# Altium schematic sheet lv_com_rs232_rs485.SchDoc
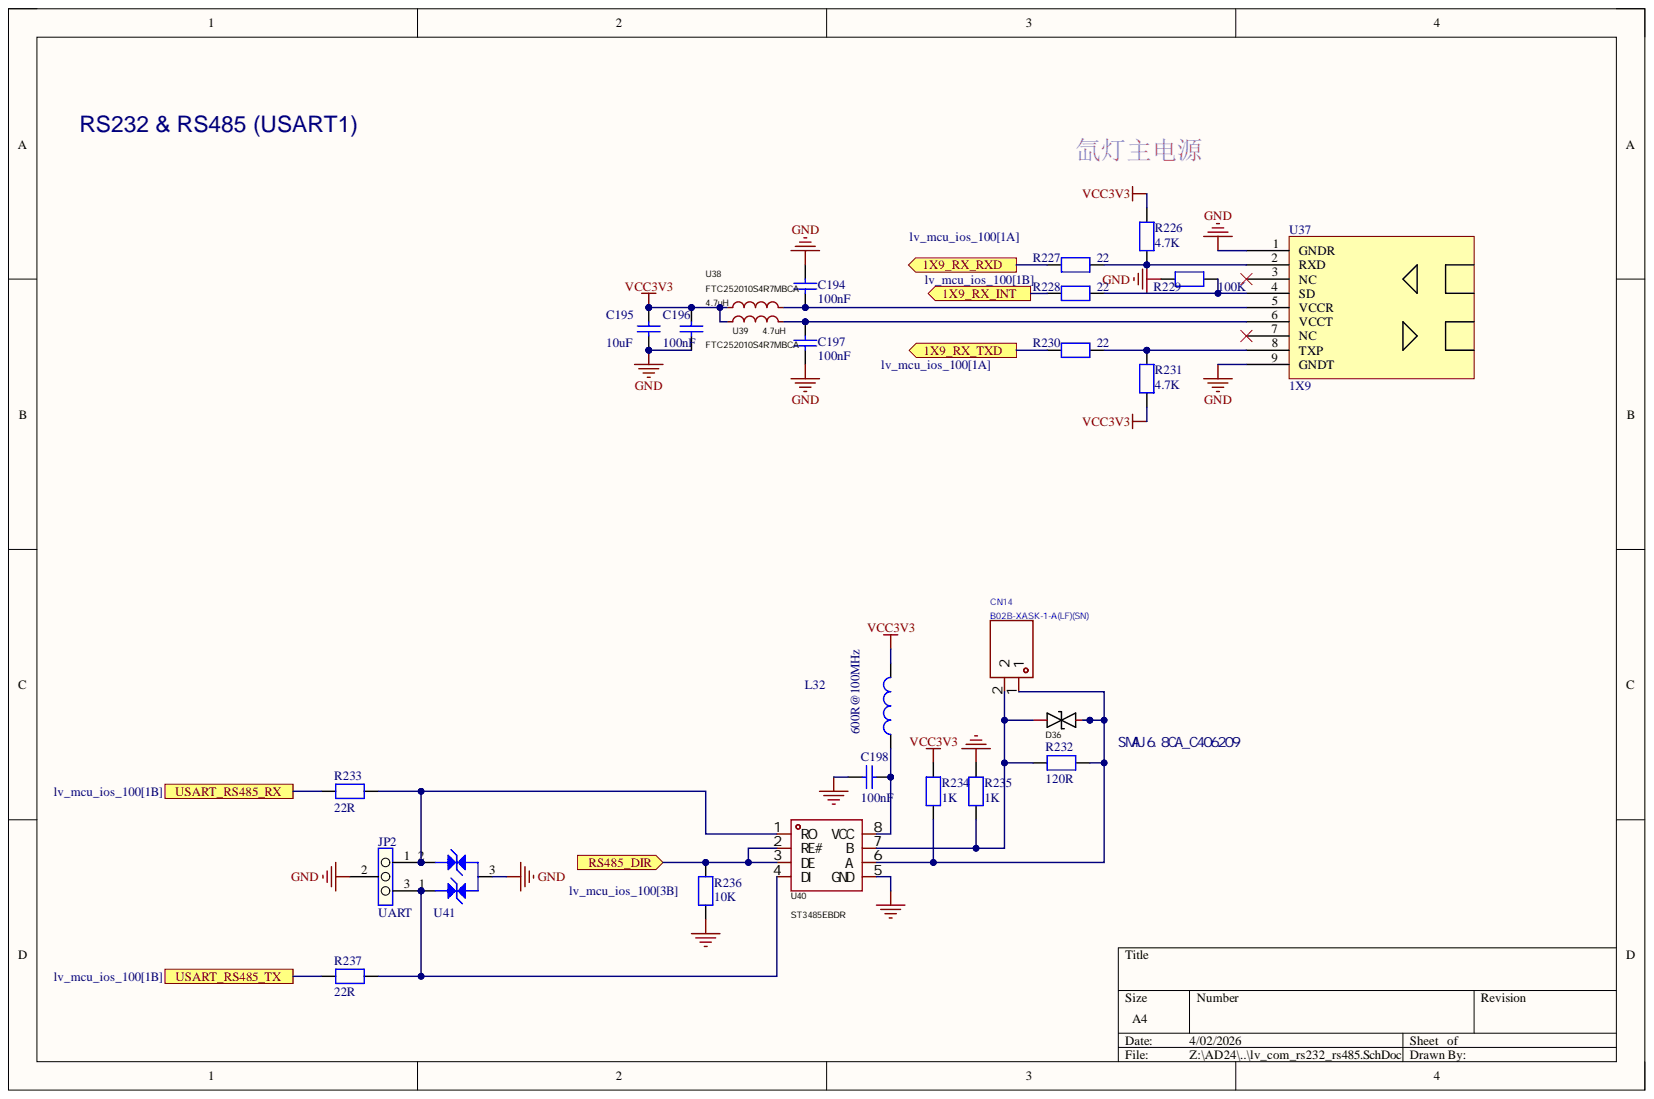
[diagram p0: lv_com_rs232_rs485.SchDoc]

## Parts
C194  100nF  [C0402]
C195  10uF  [C0805]
C196  100nF  [C0402]
C197  100nF  [C0402]
C198  100nF  [C0402]
CN14  B02B-XASK-1-A(LF)(SN)  [CONN-TH_B02B-XASK-1-A-LF-SN]
D36  SMAJ6.8CA_C406209  [SMA_L4.4-W2.6-LS5.0-BI]
JP2  UART  [CON_SAMTEC_TSW-103-07-F-S]
L32  600R@100MHz  [L0603]
R226  4.7K  [R0402]
R227  22  [R0402]
R228  22  [R0402]
R229  100K  [R0402]
R230  22  [R0402]
R231  4.7K  [R0402]
R232  120R  [0805]
R233  22R  [R0402]
R234  1K  [R0603]
R235  1K  [R0603]
R236  10K  [R0402]
R237  22R  [R0402]
U37  1X9  [1X9]
U38  4.7uH  [IND-SMD_L2.5-W2.0-VLS252012CX-150M]
U39  4.7uH  [IND-SMD_L2.5-W2.0-VLS252012CX-150M]
U40  ST3485EBDR  [SO-8_L4.9-W3.9-P1.27-LS5.9-BL]
U41  ESDCAN03-2BWY  [DIO_SOT323]  (value not drawn)

## Ports
1X9_RX_INT, 1X9_RX_RXD, 1X9_RX_TXD, RS485_DIR, USART_RS485_RX, USART_RS485_TX

## Nets
GND: C194.2 C195.1 C196.1 C197.1 C198.1 JP2.2 R229.1 R235.2 R236.1 U37.1(GNDR) U37.9(GNDT) U40.5(GND) U41.3
VCC3V3: C195.2 C196.2 L32.2 R226.2 R231.2 R234.2 U38.1 U39.1
1X9_RX_INT: R228.2 ; port 1X9_RX_INT
1X9_RX_RXD: R227.2 ; port 1X9_RX_RXD
1X9_RX_TXD: R230.1 ; port 1X9_RX_TXD
RS485_DIR: R236.2 U40.2(RE#) U40.3(DE) ; port RS485_DIR
USART_RS485_RX: R233.1 ; port USART_RS485_RX
USART_RS485_TX: R237.1 ; port USART_RS485_TX
NetC194_1: C194.1 U37.5(VCCR) U38.2
NetC197_2: C197.2 U37.6(VCCT) U39.2
NetC198_2: C198.2 L32.1 U40.8(VCC)
NetCN14_1: CN14.1 D36.2 R232.1 R234.1 U40.6(A)
NetCN14_2: CN14.2 D36.1 R232.2 R235.1 U40.7(B)
NetJP2_1: JP2.1 R233.2 U40.1(RO) U41.2
NetJP2_3: JP2.3 R237.2 U40.4(DI) U41.1
NetR226_1: R226.1 R227.1 U37.2(RXD)
NetR228_1: R228.1 R229.2 U37.4(SD)
NetR230_2: R230.2 R231.1 U37.8(TXP)

Not connected: U37 3(NC) 7(NC)

## Library data of the parts
C194 C196 C197 = Capacitor_1: 50V, X7R, 10%; Manufacturer: muRata; Manufacturer_Part_Number: GRM188R71H104KA93D; Component Kind: Standard; ComponentLink1Description: Yageo_Capacitor_X5R-series.pdf; ComponentLink1URL: http://tunmcdsvn.tun.st.com/Component_Datasheet_Library/Trunk/Capacitors/Yageo_Multilayer_capacitor_X5R_series_100pF_to_100uF; Library Name: HiPin_Library_AD.IntLib
C195 = Capacitor_1: 50V, X5R, 20%; Manufacturer: muRata; Manufacturer_Part_Number: GRM21BR61H106KE43L; Component Kind: Standard; ComponentLink1Description: Yageo_Capacitor_X5R-series.pdf; ComponentLink1URL: http://tunmcdsvn.tun.st.com/Component_Datasheet_Library/Trunk/Capacitors/Yageo_Multilayer_capacitor_X5R_series_100pF_to_100uF; Library Name: HiPin_Library_AD.IntLib
C198 = Capacitor: 50V, 10%, X7R; Manufacturer_Part_Number: GRM155R61E105KA12D; Component Kind: Standard; ComponentLink1Description: Datasheet; ComponentLink1URL: http://tunmcdsvn.tun.st.com/Component_Datasheet_Library/Trunk/Capacitors/Yageo_Capacitor_NP0_16V-to-50V; Library Name: HiPin_Library_AD.IntLib; Package: C0402; Value: 100nF
CN14 = B02B-XASK-1-A(LF)(SN): XA插座，间距: 2.5mm，直插，H8.8mm; Manufacturer: JST; Add into BOM: yes; Current Rating (Max): 3A; Flame Retardant Rating: UL94V-0; Number of PINs Per Row: 2; Pins Structure: 1x2P; Pitch: 2.5mm; Plastic Material: 玻纤增强PA66; Rated Voltage (Max): 250V; Reference Series: XA; Supplementary Features: 带柱；打K脚; X-Length of Bottom Edge on Board (Spacing Line): 7.5mm; Y-Width of Bottom Edge on Board: 6.4mm; Z-Height of the Board: 8.8mm; Colour: 白色; Component Kind: Standard; Contact Material: 铜合金; Contact Plating: 锡; Convert to PCB: yes; Datasheet: https://atta.szlcsc.com/upload/public/pdf/source/20180829/C265077_AACBE43BFB9344D794AFC81CB60287FF.pdf; Device: B02B-XASK-1-A(LF)(SN); JLCPCB Part Class: Extended Part; LCSC Part Name: 1x2P 间距:2.5mm 直插 系列:XA; Library Name: HiPin_Library_AD.IntLib; Manufacturer Part: B02B-XASK-1-A(LF)(SN); Mounting Style: 直插; Number of Pins: 2P; Number of Rows: 1; Operating Temperature Range: -25℃~+85℃; PCB3D: CONN-TH_B02B-XASK-1-A-LF-SN; Row Spacing: -; Supplier: LCSC; Supplier Footprint: 插件,P=2.5mm; Supplier Part: C265077; Symbol: B02B-XASK-1-A(LF)(SN)
D36 = SMAJ6.8CA_C406209: Manufacturer: BORN(�����뵼��); Add into BOM: yes; Reverse Stand-Off Voltage (Vrwm): 6.8V; Type: TVS; Component Kind: Standard; Convert to PCB: yes; Datasheet: https://atta.szlcsc.com/upload/public/pdf/source/20190726/C406209_A574BC8626299E1E05AC1B67D2991239.pdf; Device: SMAJ6.8CA_C406209; JLCPCB Part Class: Extended Part; LCSC Part Name: ˫��TVS 6.8V��ֹ; Library Name: HiPin_Library_AD.IntLib; Manufacturer Part: SMAJ6.8CA; Supplier: LCSC; Supplier Footprint: SMA(DO-214AC); Supplier Part: C406209; Symbol: SMAJ6.8CA
JP2 = MCD-00111: Header, 3x1, 2,54mm, VR, TH, Height 5.84mm/2.54mm; Manufacturer: SAMTEC; Manufacturer_Part_Number: TSW-103-07-F-S; Part_Type: Connector; Initial_Board_Reference: MB1262; Item Type: Item; Path: ws/MCD_ApplicationBoards/Lists/MCDPARTSdatabase; Provided_By: SUBCO; Value: Header 3x1; Component Kind: Standard; ComponentLink1Description: Datasheet; ComponentLink1URL: http://tunmcdsvn.tun.st.com/Component_Datasheet_Library/Trunk/Connectors/Samtec_TSW-HTSW-series; Internal_Part_Number: MCD-00111; Library Name: MB1397.IntLib; Status: Released
L32 = MCD-00047: +/-25%, 350mA, 500mohm DC; Manufacturer: TAI-TECH; Manufacturer_Part_Number: FCM1608KF-601T03; Database Table Name: MCD_PARTS$; Component Kind: Standard; ComponentLink1Description: Datasheet; ComponentLink1URL: http://tunmcdsvn.tun.st.com/Component_Datasheet_Library/Trunk/Inductors/TaiTech_Bead_FCM1608_series; Library Name: MCD_PARTS_database.DbLib
R226-R235 R237 = RES: 1%; Value: 10K; Component Kind: Standard; Library Name: HiPin_Library_AD.IntLib
R236 = RES: 1%; Component Kind: Standard; Library Name: HiPin_Library_AD.IntLib; Value: 10K
U37 = 1X9_2S: 双纤光纤收发器; Component Kind: Standard; Library Name: HiPin_Library_AD.IntLib
U38 U39 = FTC252010S4R7MBCA: 4.7uH 2A; Manufacturer: cjiang(长江微电); Add into BOM: yes; DC Resistance (DCR): 160mΩ; Saturation Current (Isat): 2.6A; Tolerance: ±20%; Component Kind: Standard; Convert to PCB: yes; Datasheet: https://atta.szlcsc.com/upload/public/pdf/source/20230602/8FFEDA9C0247A92A0D4B67554BFE4091.pdf; Device: FTC252010S4R7MBCA; JLCPCB Part Class: Extended Part; LCSC Part Name: 4.7uH ±20% 2.6A; Library Name: HiPin_Library_AD.IntLib; Manufacturer Part: FTC252010S4R7MBCA; Rated Current: 2A; Supplier: LCSC; Supplier Footprint: SMD,2x2.5mm; Supplier Part: C5832360; Symbol: FTC252010S4R7MBCA; Type: 一体成型电感; Value: 4.7uH
U40 = ST3485EBDR: RS485; Manufacturer: ST(意法半导体); Add into BOM: yes; Data Rate: 15Mbps; ESD Protect: ±12kV; Node Number: 64; Number of Driver: 1; Supply Voltage: 3V~3.6V; Types: 收发器; Component Kind: Standard; Convert to PCB: yes; Datasheet: https://atta.szlcsc.com/upload/public/pdf/source/20181012/C75809_EC9EE9FC1B4AC7950854237FAEE73CA1.pdf; Device: ST3485EBDR; JLCPCB Part Class: Extended Part; LCSC Part Name: ST3485EBDR 3.3 V供电，15 kV ESD保护，最高12 Mbps RS-485/RS-422收发器; Library Name: HiPin_Library_AD.IntLib; Manufacturer Part: ST3485EBDR; Number of receiver: 1; Operating Temperature: -40℃~+85℃; PCB3D: SO-8_L4.9-W3.9-H1.5-LS5.9-P1.27; Supplier: LCSC; Supplier Footprint: SO-8; Supplier Part: C75809; Symbol: ST3485EBDR
U41 = MCD-01228: 极性  双向  反向截止电压(Vrwm)  24V  钳位电压  41V  峰值脉冲电流(Ipp)  3.7A@8/20us; Manufacturer: STMICROELECTRONICS; Database Table Name: MCD_PARTS$; Component Kind: Standard; Library Name: MCD_PARTS_database.DbLib; Manufacturer_Part_Number: ESDCAN03-2BWY
